# Altium schematic sheet XYAmpRev2b.SchDoc
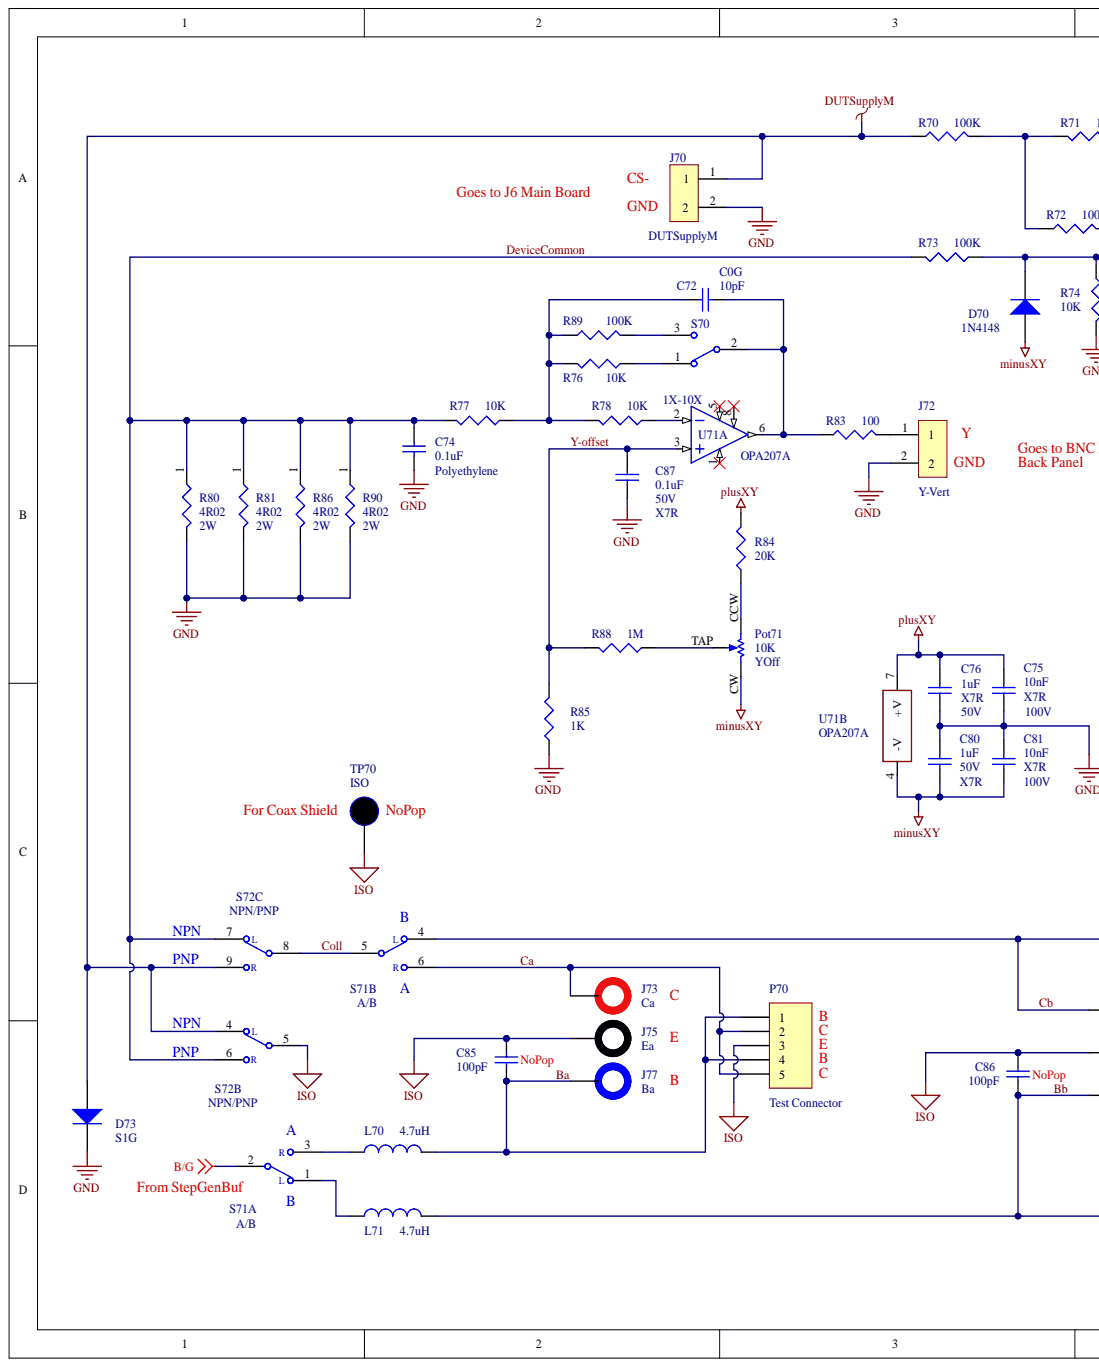
[diagram p0: XYAmpRev2b.SchDoc, left]
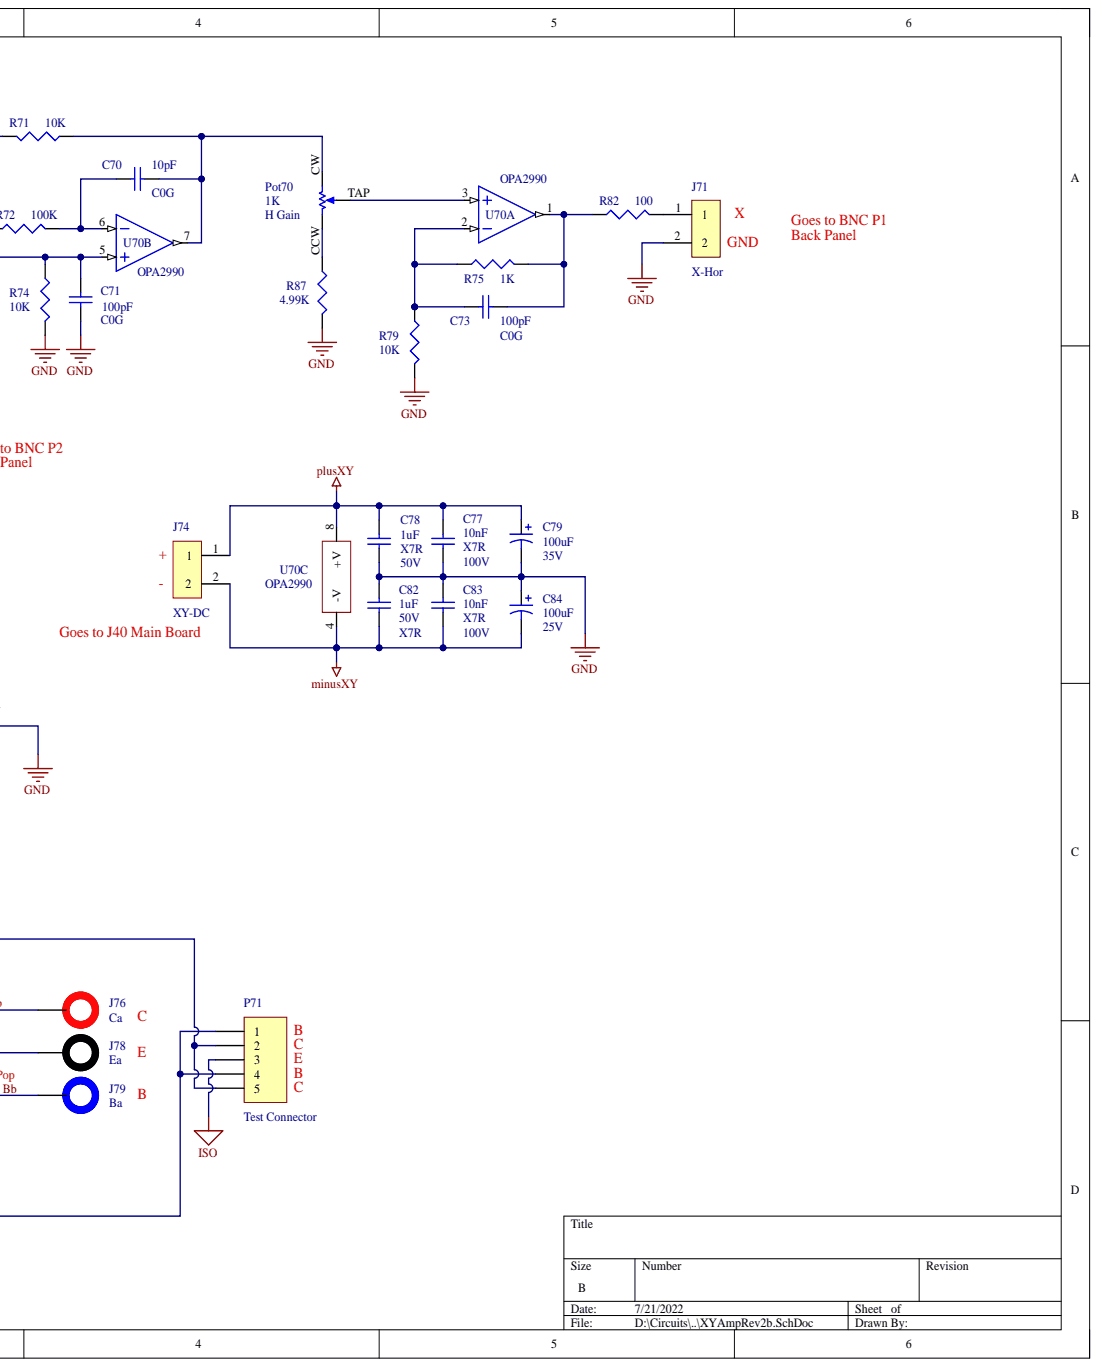
[diagram p1: XYAmpRev2b.SchDoc, right]

## Parts
C70  10pF  Dielectric: C0G  [CAPC2012M]
C71  100pF  Dielectric: C0G  [CAPC2012M]
C72  10pF  Dielectric: C0G  [CAPC2012M]
C73  100pF  Dielectric: C0G  [CAPC2012M]
C74  value 0.1uF  Dielectric Material: Polyethylene  [CAPC3225M]  (hidden comment: Cap)
C75  10nF  Dielectric: X7R  Voltage: 100V  [CAPC2012M]
C76  1uF  Dielectric: X7R  Voltage: 50V  [CAPC2012M]
C77  10nF  Dielectric: X7R  Voltage: 100V  [CAPC2012M]
C78  1uF  Dielectric: X7R  Voltage: 50V  [CAPC2012M]
C79  100uF  Voltage: 35V  [CAPPR2.5-6.3X10]
C80  1uF  Dielectric: X7R  Voltage: 50V  [CAPC2012M]
C81  10nF  Dielectric: X7R  Voltage: 100V  [CAPC2012M]
C82  1uF  Dielectric: X7R  Voltage: 50V  [CAPC2012M]
C83  10nF  Dielectric: X7R  Voltage: 100V  [CAPC2012M]
C84  100uF  Voltage: 25V  [CAPPR2-5x11]
C85  NoPop  value 100pF  [CAPC2012M]
C86  NoPop  value 100pF  [CAPC2012M]
C87  0.1uF  Dielectric: X7R  Voltage: 50V  [CAPC2012M]
D70  1N4148  [SOD123]
D73  S1G  [SMA-D]
J70  DUTSupplyM  [PHOENIX2X0.2]
J71  X-Hor  [1988956]
J72  Y-Vert  [1988956]
J73  Ca  [Banana-Turet]
J74  XY-DC  [DTA-100-2]
J75  Ea  [Banana-Turet]
J76  Ca  [Banana-Turet]
J77  Ba  [Banana-Turet]
J78  Ea  [Banana-Turet]
J79  Ba  [Banana-Turet]
L70  value 4.7uH  [CAPC3225M]  (hidden comment: Inductor)
L71  value 4.7uH  [CAPC3225M]  (hidden comment: Inductor)
P70  Test Connector  [TEST-SOCKET-5PIN]
P71  Test Connector  [TEST-SOCKET-5PIN]
Pot70  value 1K  [7mmSq-CCW]  (hidden comment: H Gain)
Pot71  YOff  value 10K  [7MM-SQ-Side]
R70  100K  [RESC3216M]
R71  10K  [RESC2012M]
R72  100K  [RESC2012M]
R73  100K  [RESC2012M]
R74  10K  [RESC2012M]
R75  1K  [RESC2012M]
R76  10K  [RESC2012M]
R77  10K  [RESC2012M]
R78  10K  [RESC2012M]
R79  10K  [RESC2012M]
R80  value 4R02  Power: 2W  [RESC6432BM]  (hidden comment: 1R0)
R81  value 4R02  Power: 2W  [RESC6432BM]  (hidden comment: 10R0)
R82  100  [RESC2012M]
R83  100  [RESC2012M]
R84  value 20K  [RESC2012M]  (hidden comment: 10K)
R85  1K  [RESC2012M]
R86  value 4R02  Power: 2W  [RESC6432BM]  (hidden comment: 1R0)
R87  4.99K  [RESC2012M]
R88  value 1M  [RESC2012M]  (hidden comment: 10K)
R89  100k  [RESC2012M]
R90  value 4R02  Power: 2W  [RESC6432BM]  (hidden comment: 10R0)
S70  1X-10X  [EG2361]
S71  A/B  [EG2414]  (parts A,B)
S72  NPN/PNP  [EG2425]  (parts B,C)
TP70  ISO  [TP-.038]
U70  OPA2990  [SOIC127P600X168_HS-8N]  (parts A,B,C)
U71  OPA207A  [SOIC127P600X168_HS-8N]  (parts A,B)

## Nets
B/G: S71.2
DUTSupplyM: D73.1 J70.1 R70.1 S72.4(L) S72.9(R)
GND: C71.2 C74.2 C75.2 C76.2 C77.2 C78.2 C79.2 C80.2 C81.2 C82.2 C83.2 C84.1 C87.2 D73.2 J70.2 J71.2 J72.2 R74.1 R79.1 R80.2 R81.2 R85.1 R86.2 R87.1 R90.2
ISO: C85.2 C86.2 J75.1 J78.1 P70.3 P71.3 S72.5 TP70.1
minusXY: C80.1 C81.1 C82.1 C83.1 C84.2 D70.1 J74.2 Pot71.CW U70.4(-V) U71.4(-V)
plusXY: C75.1 C76.1 C77.1 C78.1 C79.1 J74.1 R84.1 U70.8(+V) U71.7(+V)
Ba: C85.1 J77.1 L70.1 P70.1 P70.4
Bb: C86.1 J79.1 L71.1 P71.1 P71.4
Ca: J73.1 P70.2 P70.5 S71.6(R)
Cb: J76.1 P71.2 P71.5 S71.4(L)
Coll: S71.5 S72.8
DeviceCommon: C74.1 R73.2 R77.1 R80.1 R81.1 R86.1 R90.1 S72.6(R) S72.7(L)
Y-offset: C87.1 R85.2 R88.1 U71.3
NetC70_1: C70.1 R72.2 U70.6
NetC70_2: C70.2 Pot70.CW R71.2 U70.7
NetC71_1: C71.1 D70.2 R73.1 R74.2 U70.5
NetC72_1: C72.1 R76.1 R77.2 R78.1 R89.1
NetC72_2: C72.2 R83.1 S70.2 U71.6
NetC73_1: C73.1 R75.1 R79.2 U70.2
NetC73_2: C73.2 R75.2 R82.1 U70.1
NetJ71_1: J71.1 R82.2
NetJ72_1: J72.1 R83.2
NetL70_2: L70.2 S71.3(R)
NetL71_2: L71.2 S71.1(L)
NetPot70_CCW: Pot70.CCW R87.2
NetPot70_TAP: Pot70.TAP U70.3
NetPot71_CCW: Pot71.CCW R84.2
NetPot71_TAP: Pot71.TAP R88.2
NetR70_2: R70.2 R71.1 R72.1
NetR76_2: R76.2 S70.1
NetR78_2: R78.2 U71.2
NetR89_2: R89.2 S70.3

Not connected: U71 1 5 8
